# Altium schematic sheet NanoD1_Wireless.SchDoc
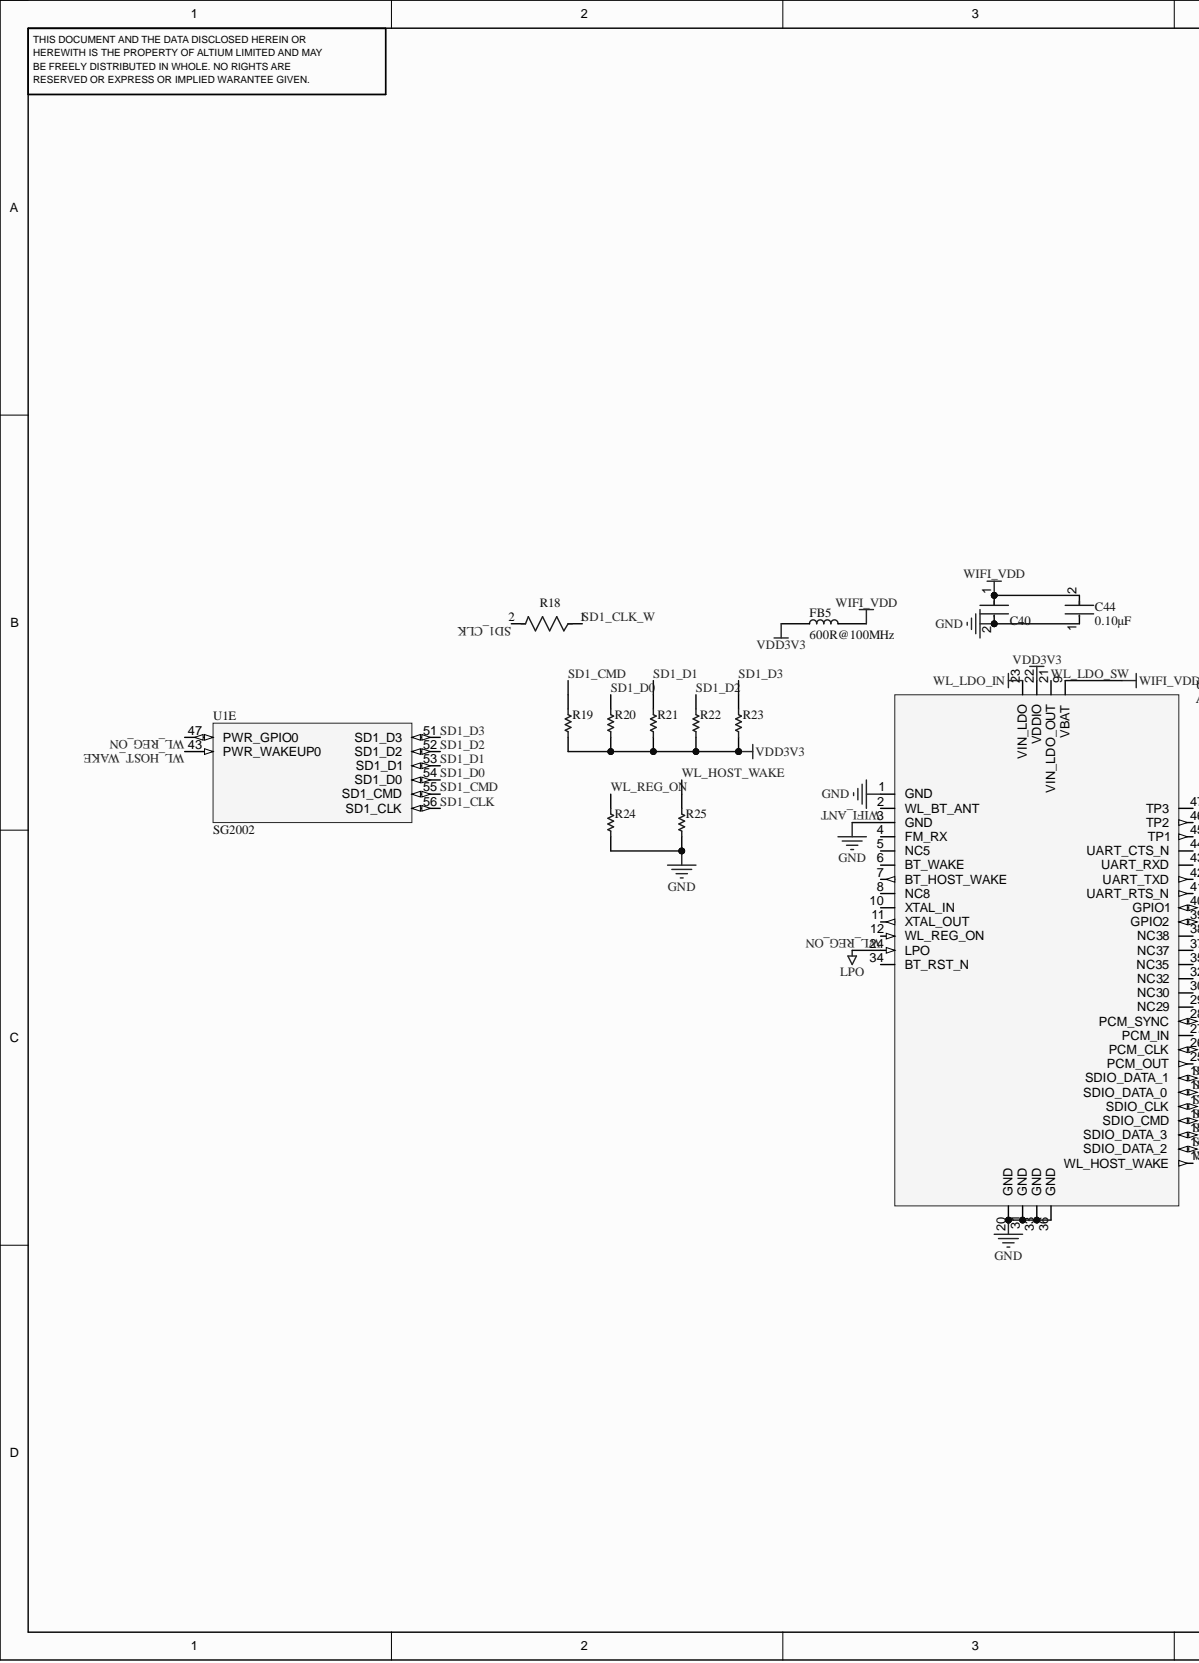
[diagram p0: NanoD1_Wireless.SchDoc, left]
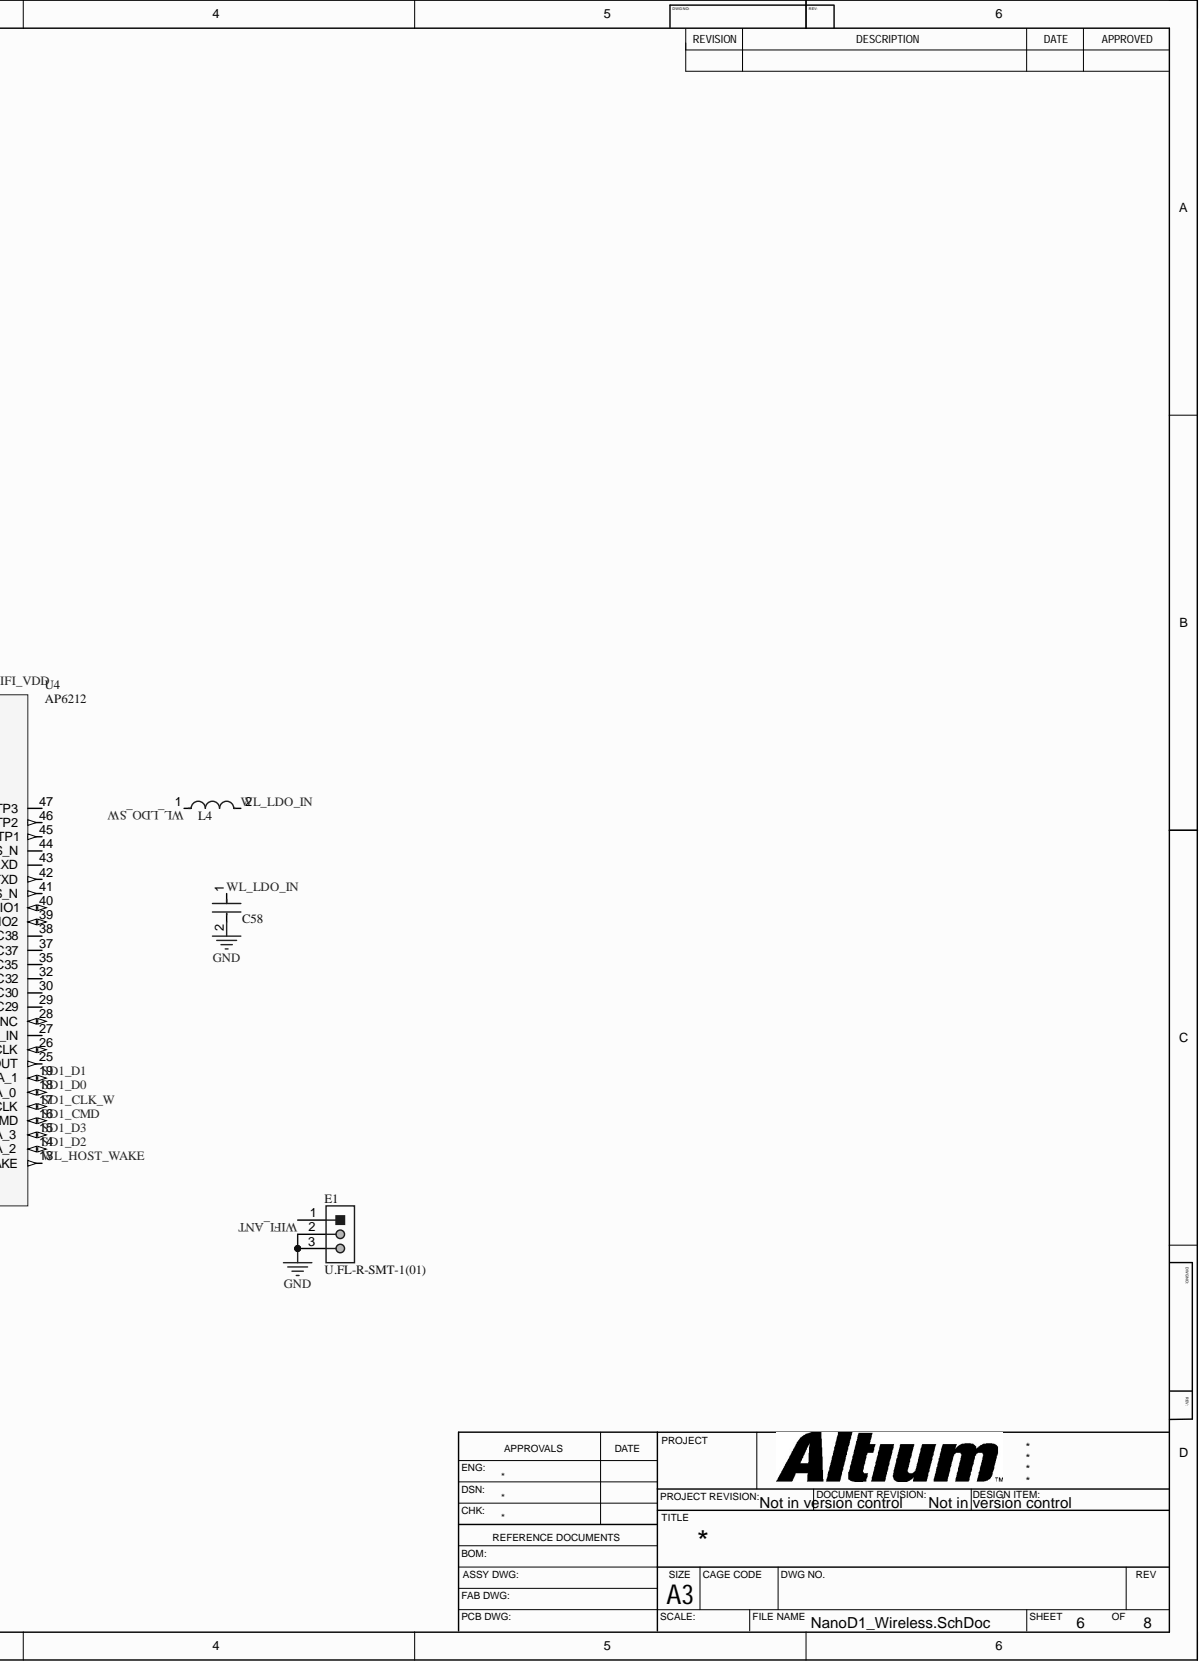
[diagram p1: NanoD1_Wireless.SchDoc, right]

## Parts
C40  10uF  [FP-GRM188-0_15-IPC_B]  (value not drawn)
C44  value 0.10μF  Capacitance: 0.10μF  [FP-GRM15-MFG]  (hidden comment: 100nF)
C58  10uF  [FP-GRM188-0_15-IPC_B]  (value not drawn)
E1  U.FL-R-SMT-1(01)  [HRS-U.FL-R-SMT-3_V]
FB5  600R@100MHz  [INDC1608X95N]
L4  4.7uH  [FP-DFE252010C-MFG]  (value not drawn)
R18  22R  [FP-RC0402-0_4-IPC_A]  (value not drawn)
R19  10K  [RESC1005X40X25ML05T10]  (value not drawn)
R20  10K  [RESC1005X40X25ML05T10]  (value not drawn)
R21  10K  [RESC1005X40X25ML05T10]  (value not drawn)
R22  10K  [RESC1005X40X25ML05T10]  (value not drawn)
R23  10K  [RESC1005X40X25ML05T10]  (value not drawn)
R24  10K  [RESC1005X40X25ML05T10]  (value not drawn)
R25  10K  [RESC1005X40X25ML05T10]  (value not drawn)
U1  SG2002  [QFN88-9x9-0.35]  (part E)
U4  AP6212  [AP6212-12x12]

## Nets
GND: C40.2 C44.1 C58.2 E1.2 E1.3 R24.1 R25.1 U4.1(GND) U4.3(GND) U4.20(GND) U4.31(GND) U4.33(GND) U4.36(GND)
LPO: U4.24(LPO)
VDD3V3: FB5.1 R19.1 R20.1 R21.1 R22.1 R23.1 U4.22(VDDIO)
WIFI_VDD: C40.1 C44.2 FB5.2 U4.9(VBAT)
SD1_CLK: R18.2 U1.56(SD1_CLK)
SD1_CLK_W: R18.1 U4.17(SDIO_CLK)
SD1_CMD: R19.2 U1.55(SD1_CMD) U4.16(SDIO_CMD)
SD1_D0: R20.2 U1.54(SD1_D0) U4.18(SDIO_DATA_0)
SD1_D1: R21.2 U1.53(SD1_D1) U4.19(SDIO_DATA_1)
SD1_D2: R22.2 U1.52(SD1_D2) U4.14(SDIO_DATA_2)
SD1_D3: R23.2 U1.51(SD1_D3) U4.15(SDIO_DATA_3)
WIFI_ANT: E1.1 U4.2(WL_BT_ANT)
WL_HOST_WAKE: R25.2 U1.43(PWR_WAKEUP0) U4.13(WL_HOST_WAKE)
WL_LDO_IN: C58.1 L4.2 U4.23(VIN_LDO)
WL_LDO_SW: L4.1 U4.21(VIN_LDO_OUT)
WL_REG_ON: R24.2 U1.47(PWR_GPIO0) U4.12(WL_REG_ON)

Not connected: U4 4(FM_RX) 5(NC5) 6(BT_WAKE) 7(BT_HOST_WAKE) 8(NC8) 10(XTAL_IN) 11(XTAL_OUT) 25(PCM_OUT) 26(PCM_CLK) 27(PCM_IN) 28(PCM_SYNC) 29(NC29) 30(NC30) 32(NC32) 34(BT_RST_N) 35(NC35) 37(NC37) 38(NC38) 39(GPIO2) 40(GPIO1) 41(UART_RTS_N) 42(UART_TXD) 43(UART_RXD) 44(UART_CTS_N) 45(TP1) 46(TP2) 47(TP3)

## Library data of the parts
C40 C58 = CMP-06035-003612-1: Chip Multilayer Ceramic Capacitors for General Purpose, 0603, 10uF, X5R, 15%, 20%, 10V; Capacitance: 10uF; Case Code (Imperial): 0603; Case Code (Metric): 1608; Case/Package: 0603; Component Kind: Standard; Depth: 0.8mm; Dielectric: X5R; Height: 0.8mm; Lead Free: Lead Free; Length: 1.6mm; Library Name: GRM188R61A106ME69D.IntLib; Material: Ceramic; Max Operating Temperature: 85°C; Min Operating Temperature: -55°C; Mount: Surface Mount; Package Quantity: 4000; Packaging: Tape and Reel; Termination: SMD/SMT; Thickness: 0.035inch; Tolerance: 20%; Voltage Rating: 10V; Voltage Rating (DC): 10V; Weight: 0.000222oz; Width: 0.8mm
C44 = CMP-2100-03641-2: CAP CER 0.1UF 16V X7R 0402; Manufacturer: Murata; Manufacturer Part Number: GRM155R71C104KA88D; Material: Ceramic; Standoff Height: 0mm; Tolerance: 10%; Voltage Rating: 16Vdc; Category: Cap; Component Kind: Standard; ComponentLink2Description: Datasheet; ComponentLink2URL: https://search.murata.co.jp/Ceramy/image/img/A01X/G101/ENG/GRM155R71H471KA01-01A.pdf; Device Class L1: Passive Components; Device Class L2: Capacitors; Device Class L3: Ceramic Capacitors; Digikey Description: CAP CER 0.1UF 16V X7R 0402; Height: 0.55mm; Ipc Land Pattern Name: CAPC10050X219; Lead Free: yes; Library Name: GRM155R71C104KA88D.IntLib; Mouser Description: 0402 0.1 uF 16V ±10 % Tolerance X7R SMT Multilayer Ceramic Capacitor; Octopart: https://octopart.com/grm155r71c104ka88d-murata-196880; Package: GRM15; RoHS: TRUE; Temperature: -55°C to +125°C; Temperature Characteristic: X7R; Temperature Range High: +125°C; Temperature Range Low: -55°C
E1 = CMP-2000-05153-1: Ultra Small Coaxial Connector, 6 GHz, -40 to 90 degC, 3-Pin SMD, RoHS, Bulk; Manufacturer: Hirose Electric; Body Finish: Silver; Body Material: PhosphorBronze; Center Contact Plating: Gold; Connector Style: U.FL; Connector Type: Jack,MalePin; Contact Termination: Solder; Dielectric Material: LiquidCrystalPolymer(LCP); Dynamic Catalog: U.FLSeries; Featured Product: HiroseU.FLSeries; Frequency - Max: 6GHz; Impedance: 50Ohm; Minimum Quantity: UltraMiniatureCoaxial; Other Names: H122041  U.FL-R-SMT-1(01)-ND; Category: Connectors,Interconnects; Center Contact Material: PhosphorBronze; Component Kind: Standard; Datasheet URL: https://datasheet.ciiva.com/26945/getdatasheetpartid-475239-26945414.pdf; Datasheet Version: Feb-09; Family: CoaxialConnectors(RF); Fastening Type: Snap-On; Housing Color: Silver; Library Name: Altium Content Vault; Manufacturer URL: https://www.hirose.com; Mounting Type: SurfaceMount; Online Catalog: U.FLSeries; Operating Temperature: -40degC~90degC; Package Description: 3-Pin Surface Mount Device, Body 2.6 x 2.6 mm; Package Reference: U.FL-R-SMT; Packaging: Bulk; Quantity Available: Bulk; Series: U.FL; Shield Termination: Solder; Standard Package: 100; Unit PriceUSD: U.FL
FB5 = MPZ1608S601ATA00: 600 Ohms @ 100 MHz 1 Power Line Ferrite Bead 0603 (1608 Metric) 1A 150mOhm; MF: TDK; Check_prices: https://www.snapeda.com/parts/MPZ1608S601ATA00/TDK/view-part/?ref=eda; Component Kind: Standard; Library Name: MPZ1608S601ATA00.IntLib; MP: MPZ1608S601ATA00; Package: 0603 TDK; SnapEDA_Link: https://www.snapeda.com/parts/MPZ1608S601ATA00/TDK/view-part/?ref=snap; Value: 600R@100MHz
L4 = CMP-06042-014898-1: Shielded Wirewound Inductor 4.7uH  1100mA 0.27Ω 1008 (2520); Core Material: Ferrite; Current Rating: 1.7A; DC Resistance (DCR): 270mR; Max DC Current: 1.1A; Test Frequency: 1MHz; Tolerance: 20%; Case Code (Imperial): 1008; Case Code (Metric): 2520; Case/Package: 1008; Component Kind: Standard; Depth: 2mm; Height - Seated (Max): 0.039inch; Inductance: 4.7uH; Length: 0.098inch; Library Name: DFE252010P-4R7M.IntLib; Max Operating Temperature: 125°C; Min Operating Temperature: -40°C; Mount: Surface Mount; Packaging: Tape and Reel; Resistor Type: Wirewound; Shielding: Shielded; Width: 0.079inch
R18 = CMP-2002-07520-2: Power Rating: 63mW; Resistance: 22Ω; Temperature Coefficient: 100ppm/°C; Tolerance: 1%; Voltage Rating: 50V; Case Code (Imperial): 0402; Case/Package: 0402; Component Kind: Standard; Composition: Thick Film; Depth: 500µm; Height: 400µm; Lead Free: Lead Free; Length: 1mm; Library Name: RC0402FR-0722RL.IntLib; Max Operating Temperature: 155°C; Military Standard: Not; Min Operating Temperature: -55°C; Number of Pins: 2; Packaging: Tape & Reel; REACH SVHC: Unknown; RoHS: Compliant; Schedule B: 8533210045, 8533210045|8533210045|8533210045|8533210045|8533210045|8533210045|8533210045|8533210045, 8533210045|8533210045|8533210045|8533210045|8533210045|8533210045|8533210045|8533210045|8533210045, 8533210045|8533210045|8533210045|8533210045|8533210045|8533210045|8533210045|8533210045|8533210045|8533210045; Termination: SMD/SMT; Voltage Rating (AC): 50V; Voltage Rating (DC): 50V; Width: 500µm
R19-R25 = CMP-2002-07353-1: Manufacturer: Yageo / Phycomp; Part Number: RC0402FR-0710KL; Component Kind: Standard; Library Name: RC0402FR-0710KL.IntLib
U1 = SG2002: RISC-V C906 / Cortex-A53 SoC, 256MB DDR3 SiP, QFN88 9x9 0.35mm; Component Kind: Standard; Library Name: NanoD1.SchLib
U4 = AP6212: Component Kind: Standard; Library Name: NanoD1.SchLib
